# Altium schematic sheet 03_B2B.SchDoc(03_B2B)
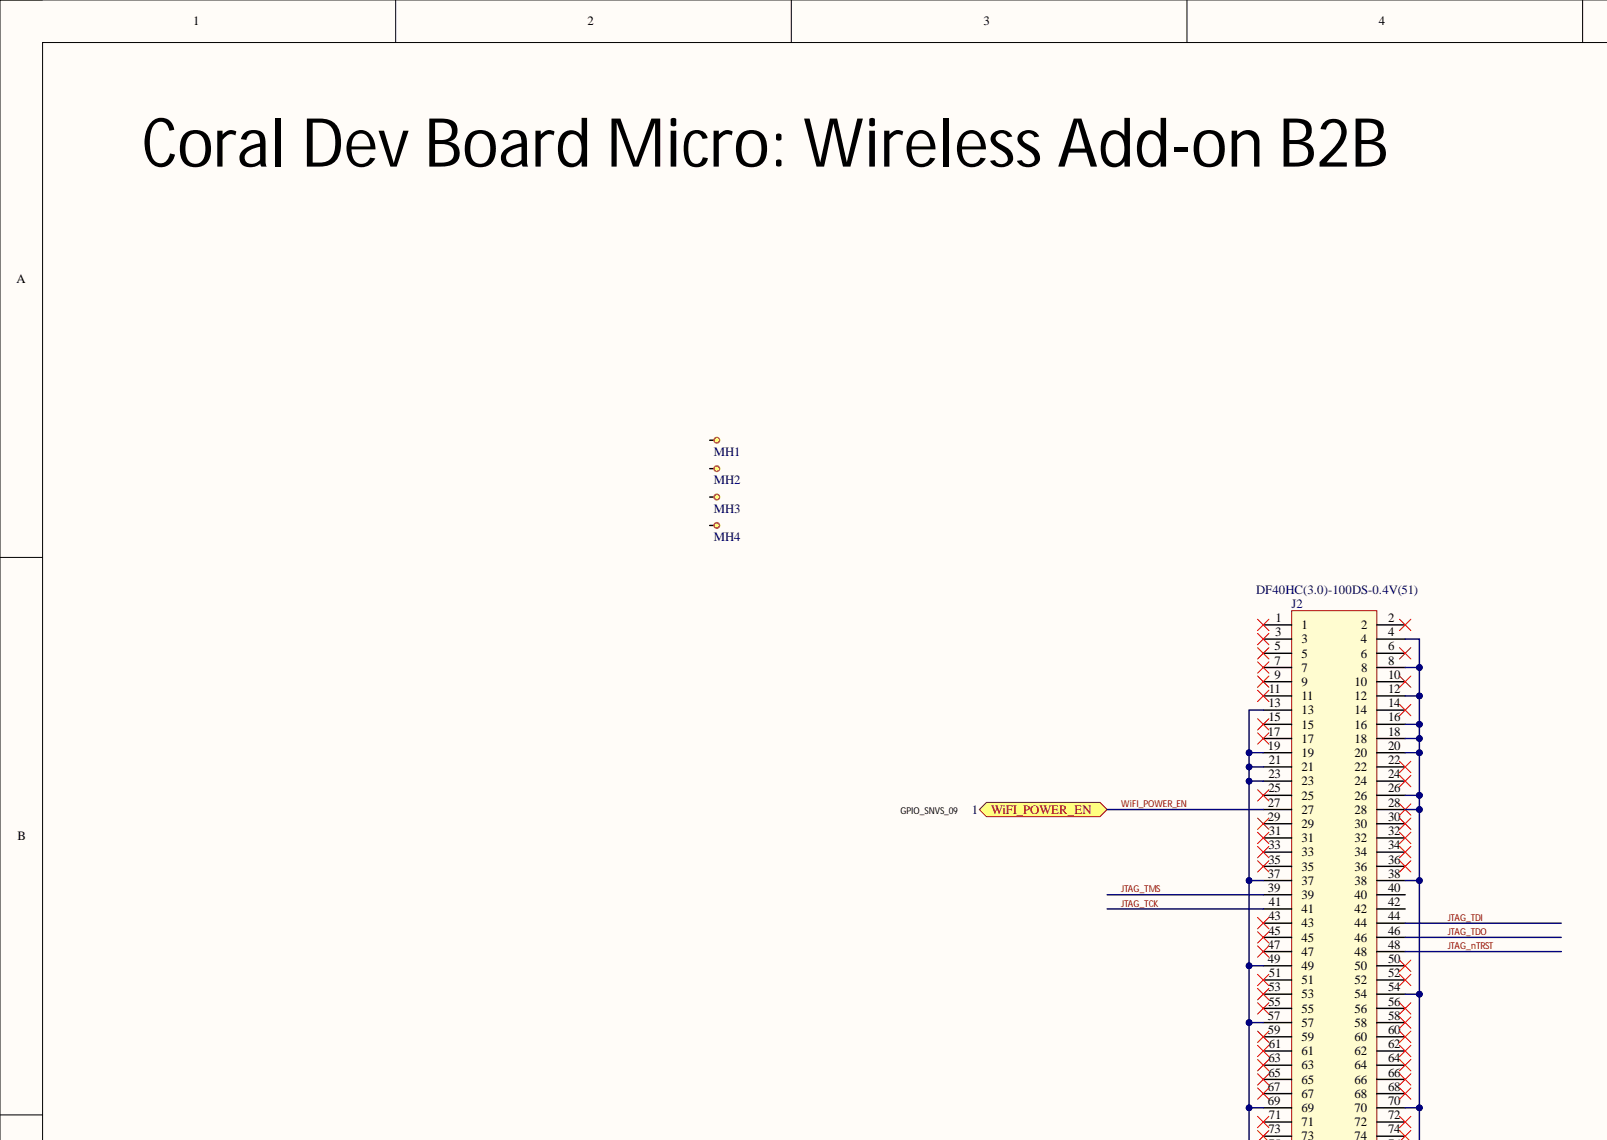
[diagram p0: 03_B2B.SchDoc, top left]
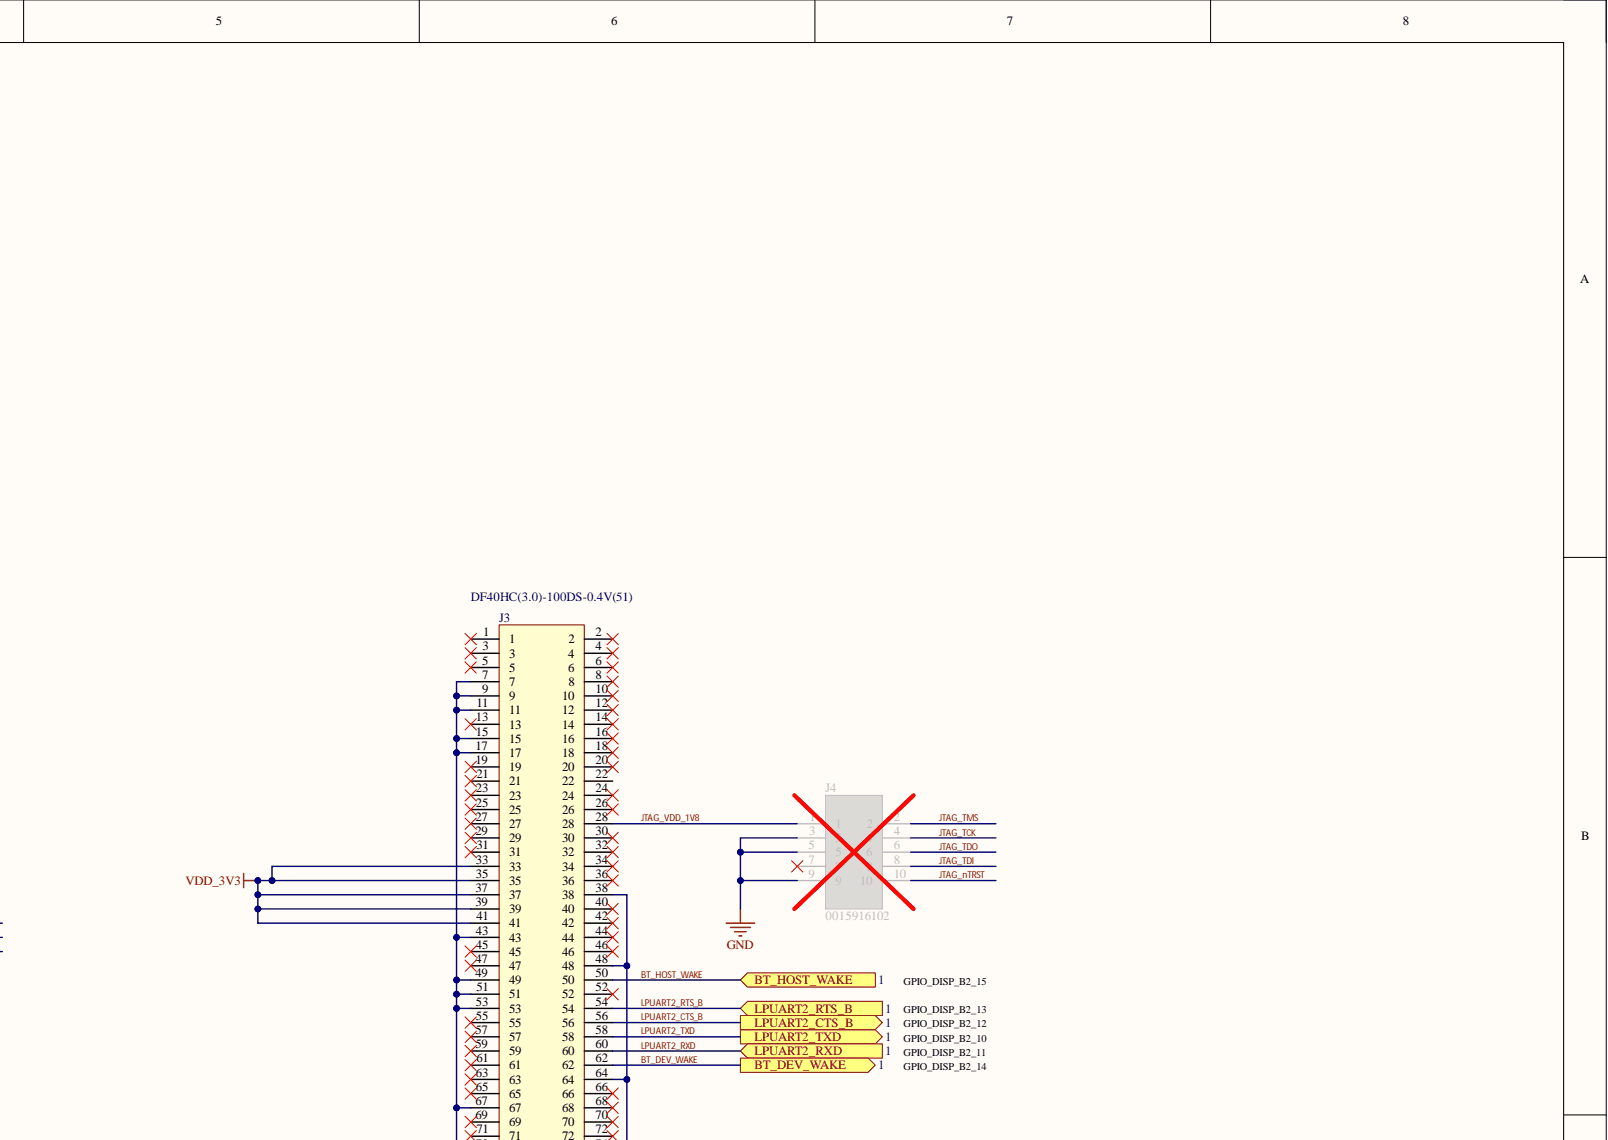
[diagram p1: 03_B2B.SchDoc, top right]
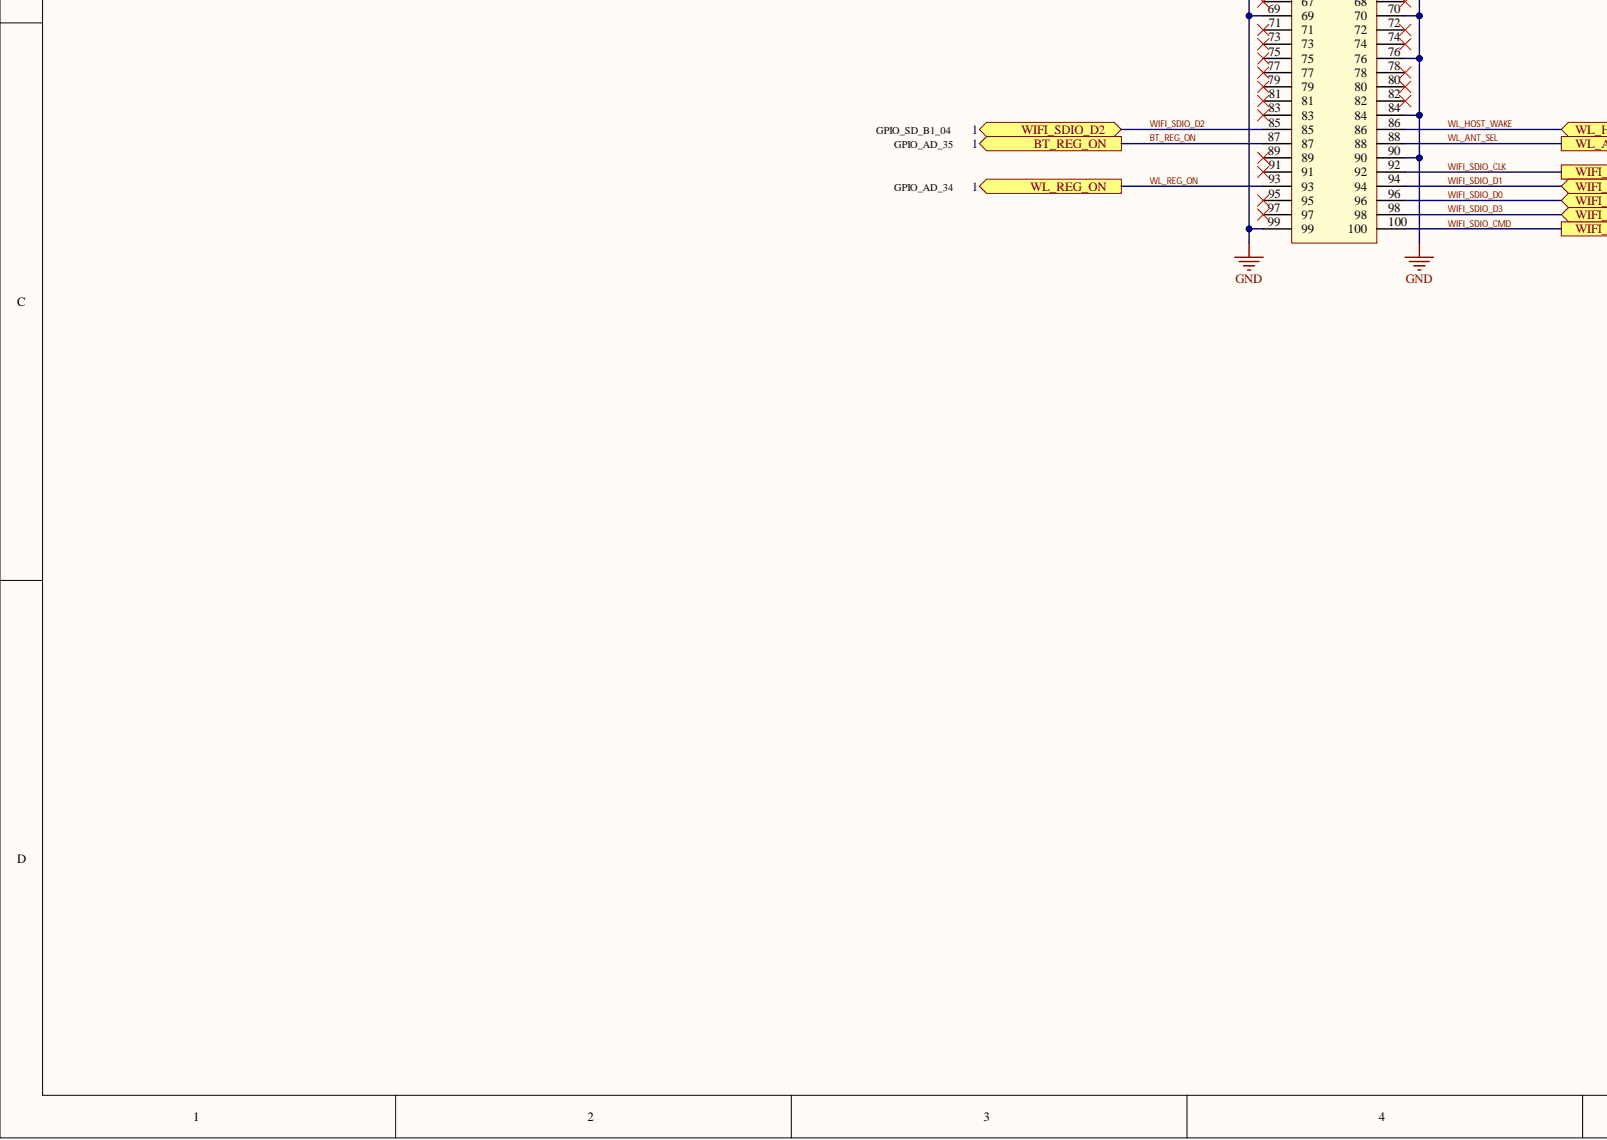
[diagram p2: 03_B2B.SchDoc, bottom left]
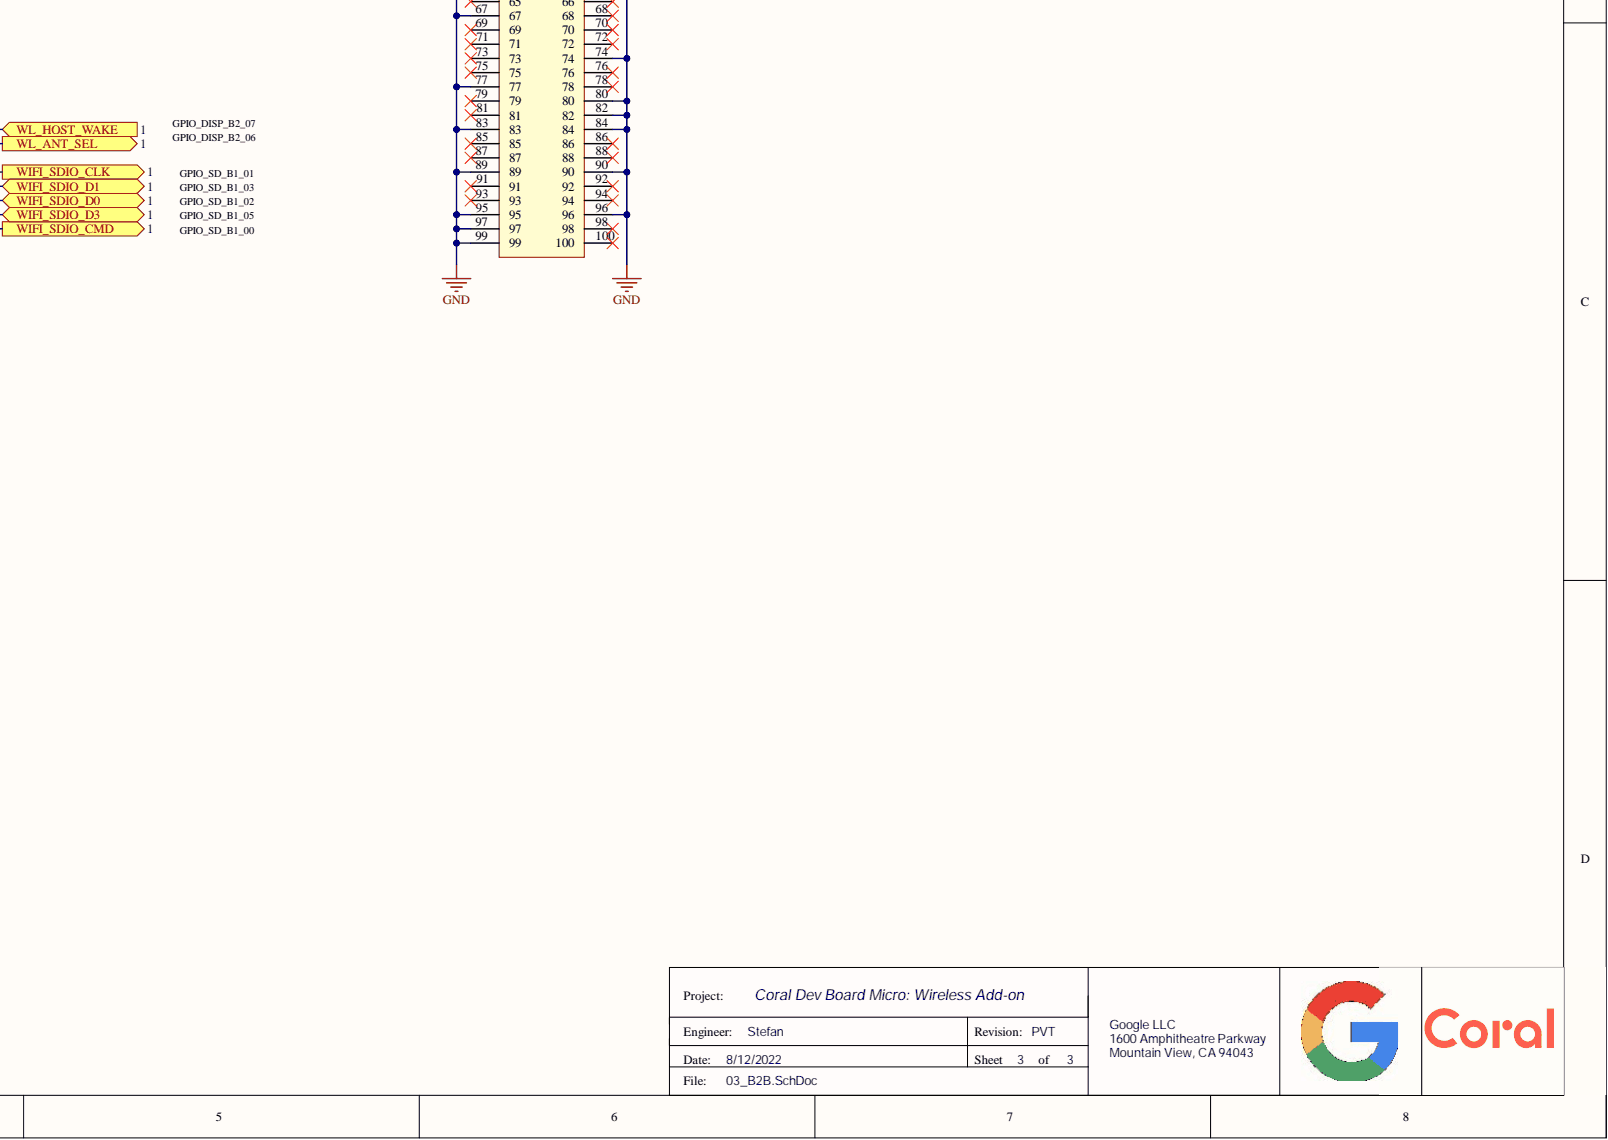
[diagram p3: 03_B2B.SchDoc, bottom right]

## Parts
J2  MFG P/N: DF40HC(3.0)-100DS-0.4V(51)  [HIROSE_DF40HC(3.0)-100DS-0.4V(51)]
J3  MFG P/N: DF40HC(3.0)-100DS-0.4V(51)  [HIROSE_DF40HC(3.0)-100DS-0.4V(51)]
J4  MFG P/N: 0015916102  [MOLEX_15916102]
MH1  PEM_SMTSO-M3-2ET  [WE_9774030951R]  (value not drawn)
MH2  PEM_SMTSO-M3-2ET  [WE_9774030951R]  (value not drawn)
MH3  PEM_SMTSO-M3-2ET  [WE_9774030951R]  (value not drawn)
MH4  PEM_SMTSO-M3-2ET  [WE_9774030951R]  (value not drawn)

## Ports
BT_DEV_WAKE, BT_HOST_WAKE, BT_REG_ON, LPUART2_CTS_B, LPUART2_RTS_B, LPUART2_RXD, LPUART2_TXD, WiFI_POWER_EN, WIFI_SDIO_CLK, WIFI_SDIO_CMD, WIFI_SDIO_D0, WIFI_SDIO_D1, WIFI_SDIO_D2, WIFI_SDIO_D3, WL_ANT_SEL, WL_HOST_WAKE, WL_REG_ON

## Nets
GND: J2.4 J2.8 J2.12 J2.13 J2.16 J2.18 J2.19 J2.20 J2.21 J2.23 J2.26 J2.28 J2.37 J2.38 J2.49 J2.54 J2.57 J2.69 J2.70 J2.76 J2.84 J2.90 J2.99 J3.7 J3.9 J3.11 J3.15 J3.17 J3.38 J3.43 J3.48 J3.49 J3.51 J3.53 J3.64 J3.67 J3.74 J3.77 J3.80 J3.82 J3.83 J3.84 J3.89 J3.90 J3.95 J3.96 J3.97 J3.99 J4.3 J4.5 J4.9
VDD_3V3: J3.33 J3.35 J3.37 J3.39 J3.41
BT_DEV_WAKE: J3.62 ; port BT_DEV_WAKE
BT_HOST_WAKE: J3.50 ; port BT_HOST_WAKE
BT_REG_ON: J2.87 ; port BT_REG_ON
JTAG_nTRST: J2.48 J4.10
JTAG_TCK: J2.41 J4.4
JTAG_TDI: J2.44 J4.8
JTAG_TDO: J2.46 J4.6
JTAG_TMS: J2.39 J4.2
JTAG_VDD_1V8: J3.28 J4.1
LPUART2_CTS_B: J3.56 ; port LPUART2_CTS_B
LPUART2_RTS_B: J3.54 ; port LPUART2_RTS_B
LPUART2_RXD: J3.60 ; port LPUART2_RXD
LPUART2_TXD: J3.58 ; port LPUART2_TXD
WiFI_POWER_EN: J2.27 ; port WiFI_POWER_EN
WIFI_SDIO_CLK: J2.92 ; port WIFI_SDIO_CLK
WIFI_SDIO_CMD: J2.100 ; port WIFI_SDIO_CMD
WIFI_SDIO_D0: J2.96 ; port WIFI_SDIO_D0
WIFI_SDIO_D1: J2.94 ; port WIFI_SDIO_D1
WIFI_SDIO_D2: J2.85 ; port WIFI_SDIO_D2
WIFI_SDIO_D3: J2.98 ; port WIFI_SDIO_D3
WL_ANT_SEL: J2.88 ; port WL_ANT_SEL
WL_HOST_WAKE: J2.86 ; port WL_HOST_WAKE
WL_REG_ON: J2.93 ; port WL_REG_ON

Not connected: J2 1 2 3 5 6 7 9 10 11 14 15 17 22 24 25 29 30 31 32 33 34 35 36 40 42 43 45 47 50 51 52 53 55 56 58 59 60 61 62 63 64 65 66 67 68 71 72 73 74 75 77 78 79 80 81 82 83 89 91 95 97; J3 1 2 3 4 5 6 8 10 12 13 14 16 18 19 20 21 22 23 24 25 26 27 29 30 31 32 34 36 40 42 44 45 46 47 52 55 57 59 61 63 65 66 68 69 70 71 72 73 75 76 78 79 81 85 86 87 88 91 92 93 94 98 100; J4 7; MH1 1; MH2 1; MH3 1; MH4 1

## Library data of the parts
J2 J3 = 1252: CONN, RCPT, CENTER STRIP, 100 P, 0.4MM PITCH, 2.9MM H, GOLD, SMD; Connector Type: Receptacle, Center Strip Contacts; Database Table Name: Connectors; MFG: Hirose Electric Co Ltd; Number of Positions: 100; Pitch: 0.40mm; Sub Assy Qty 2: 0; Component Kind: Standard; ComponentLink1Description: Datasheet; ComponentLink1URL: https://drive.google.com/file/d/1CAt5l5Yqq5tcten62VZOFv5_vQ-Vqtjc/view; Height: 3.05mm; HelpURL: https://www.digikey.com/en/products/detail/hirose-electric-co-ltd/DF40HC-3-0-100DS-0-4V-51/4282911?s=N4IgTCBcDaICIDEAsAGAEgYQBQGYB0KAlALQCMKKcAysSnkgGpYCsphIAugL5A; ID: 1252; Library Name: AltiumReleaseLibDB.DBLib; Mounting Type: Surface Mount; Number of Rows: 2; RoHS Compliant: Yes; Status: Released; Sub Assy Qty 3: 0; Sub Assy Qty 4: 0; Supplier 1: Digi-Key; Supplier Part Number 1: DF40HC(3.0)-100DS-0.4V(51-ND
J4 = 1167: CONN, HDR, UNSHRD, VERT, 2x5 P, 2.54MM PITCH, 9.91MM H, GOLD, SMT; Database Table Name: Connectors; EES: 210-04916-00; Gender: Header; MFG: Molex; Number of Positions: 10; Sub Assy Qty 2: 0; Component Kind: Standard; ComponentLink1Description: Datasheet; ComponentLink1URL: https://www.molex.com/pdm_docs/sd/015916102_sd.pdf; Features: Pick and Place; Height: 9.91mm; HelpURL: https://www.digikey.com/product-detail/en/molex/0015916102/WM3699DKR-ND/2421788; ID: 1167; Library Name: AltiumReleaseLibDB.DBLib; Mounting Type: Surface Mount; Number of Rows: 2; Orientation: Vertical; Pitch: 2.54mm; RoHS Compliant: Yes; Row Spacing: 2.54mm; Status: Released; Sub Assy Qty 3: 0; Sub Assy Qty 4: 0; Supplier 1: Digi-Key; Supplier Part Number 1: WM3699DKR-ND
MH1-MH4 = 123: HW, BOARD SPACER, ROUND, UNTHREADED, FEMALE, 2.72MM IN DIA, 5.1MM OUT DIA, STEEL; Database Table Name: Mechanical; MFG: Würth Elektronik; MFG P/N: 9774030951R; Component Kind: Standard; ComponentLink1Description: Datasheet; ComponentLink1URL: https://www.digikey.com/en/products/detail/w%C3%BCrth-elektronik/9774030951R/5320629?s=N4IgTCBcDaIJwHYEBYAMBmVcCsBGASiALoC%2BQA; Designator: MH?; Height: 3.1mm; ID: 123; Library Name: AltiumReleaseLibDB.DBLib; RoHS Compliant: RoHS Compliant; Supplier 1: Digi-Key; Supplier Part Number 1: 732-7086-2-ND
